# Altium schematic sheet Sheet2.SchDoc
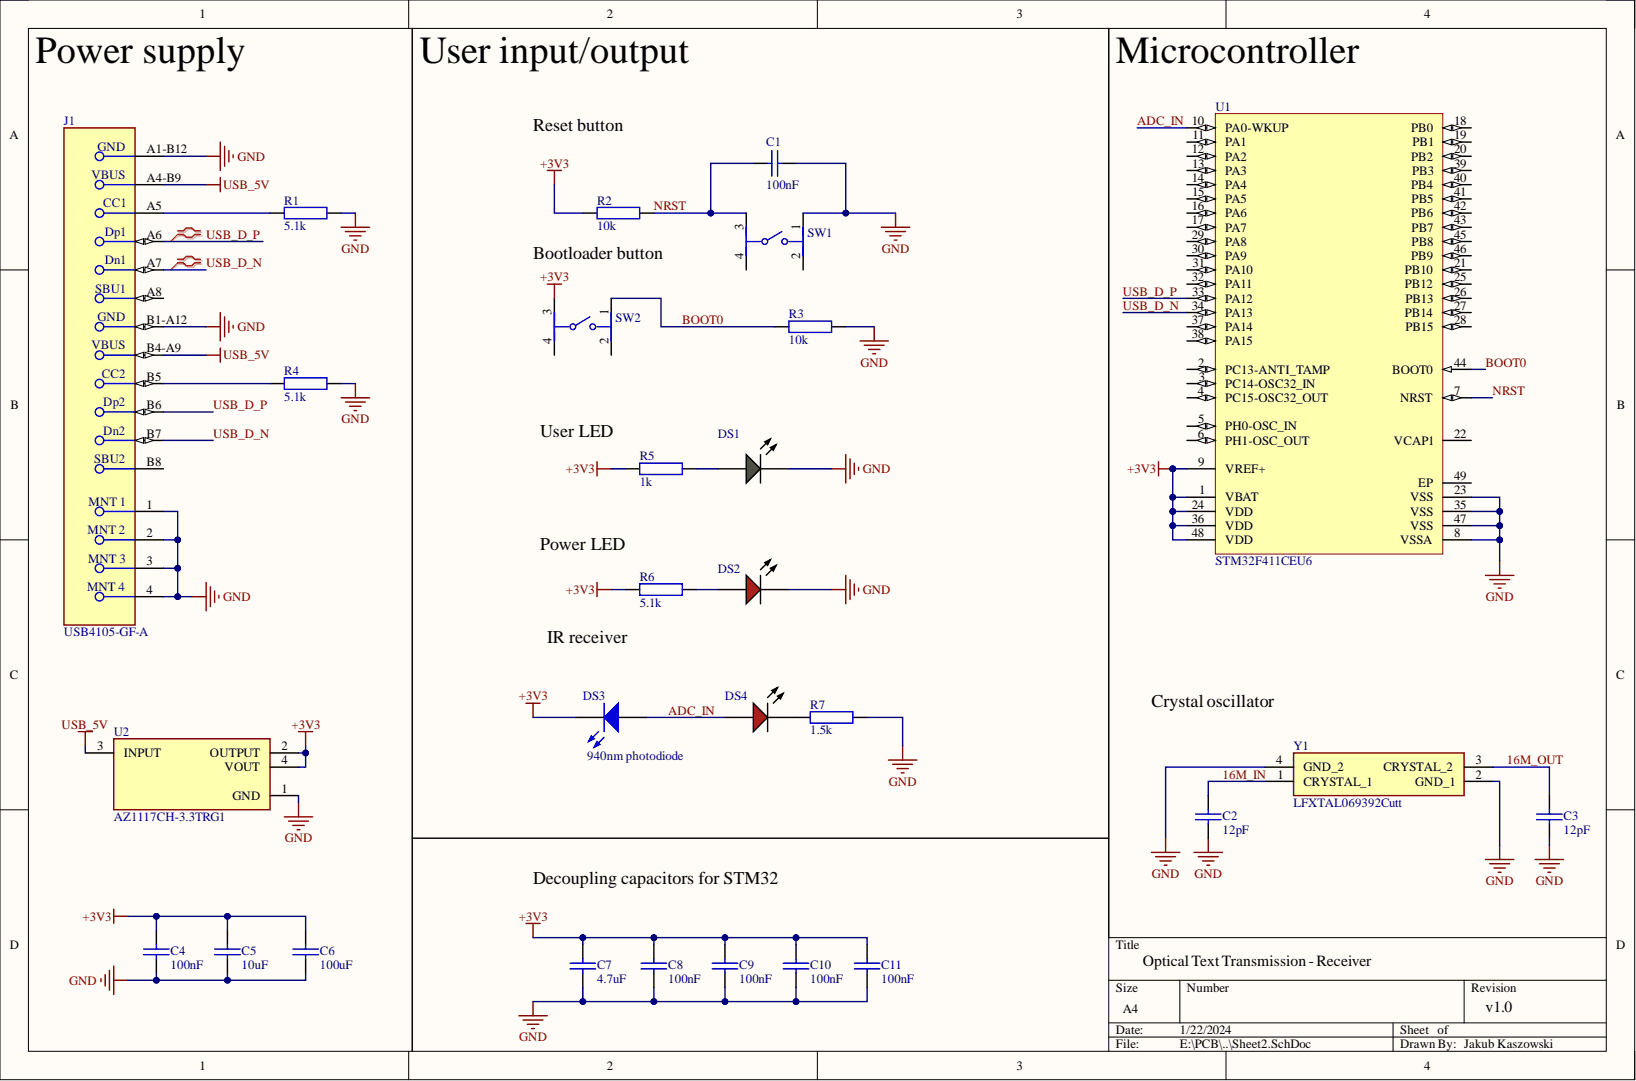
[diagram p0: Sheet2.SchDoc]

## Parts
C1  100nF  [C0603]
C2  12pF  [C0603]
C3  12pF  [C0603]
C4  100nF  [C0603]
C5  10uF  [C0603]
C6  100uF  [C1206]
C7  4.7uF  [C0603]
C8  100nF  [C0603]
C9  100nF  [C0603]
C10  100nF  [C0603]
C11  100nF  [C0603]
DS1  HSMG-C170  [AVAG-HSMX-C170_V]  (value not drawn)
DS2  TLMS1100-GS08  [VISH-TLMX1100_V]  (value not drawn)
DS3  940nm photodiode  [VISH-TSAL6200]
DS4  TLMS1100-GS08  [VISH-TLMX1100_V]  (value not drawn)
J1  USB4105-GF-A  [FP-USB4105-GF-A-MFG]
R1  5.1k  [R0603]
R2  10k  [R0603]
R3  10k  [R0603]
R4  5.1k  [R0603]
R5  1k  [R0603]
R6  5.1k  [R0603]
R7  1.5k  [R0603]
SW1  PTS810SJM250SMTRLFS  [FP-PTS810SJM250SMTRLFS-MFG]  (value not drawn)
SW2  PTS810SJM250SMTRLFS  [FP-PTS810SJM250SMTRLFS-MFG]  (value not drawn)
U1  STM32F411CEU6  [STM-UFQFPN48_L]
U2  AZ1117CH-3.3TRG1  [FP-SOT223-MFG]
Y1  LFXTAL069392Cutt  [LFXTAL069392Cutt]

## Nets
+3V3: C4.1 C5.1 C6.1 C7.1 C8.1 C9.1 C10.1 C11.1 DS3.2 R2.1 R5.1 R6.1 SW2.3 U1.1(VBAT) U1.9(VREF+) U1.24(VDD) U1.36(VDD) U1.48(VDD) U2.2(OUTPUT) U2.4(VOUT)
GND: C1.1 C2.2 C3.2 C4.2 C5.2 C6.2 C7.2 C8.2 C9.2 C10.2 C11.2 DS1.2 DS2.2 J1.1 J1.2 J1.3 J1.4 J1.A1-B12 J1.B1-A12 R1.2 R3.2 R4.2 R7.2 SW1.1 U1.8(VSSA) U1.23(VSS) U1.35(VSS) U1.47(VSS) U2.1(GND) Y1.2(GND_1) Y1.4(GND_2)
USB_5V: J1.A4-B9 J1.B4-A9 U2.3(INPUT)
16M_IN: C2.1 Y1.1(CRYSTAL_1)
16M_OUT: C3.1 Y1.3(CRYSTAL_2)
ADC_IN: DS3.1 DS4.1 U1.10(PA0-WKUP)
BOOT0: R3.1 SW2.1 U1.44(BOOT0)
NRST: C1.2 R2.2 SW1.3 U1.7(NRST)
USB_D_N: J1.A7 J1.B7 U1.34(PA13)
USB_D_P: J1.A6 J1.B6 U1.33(PA12)
NetDS1_1: DS1.1 R5.2
NetDS2_1: DS2.1 R6.2
NetDS4_2: DS4.2 R7.1
NetJ1_A5: J1.A5 R1.1
NetJ1_B5: J1.B5 R4.1

Not connected: J1 A8 B8; SW1 2 4; SW2 2 4; U1 2(PC13-ANTI_TAMP) 3(PC14-OSC32_IN) 4(PC15-OSC32_OUT) 5(PH0-OSC_IN) 6(PH1-OSC_OUT) 11(PA1) 12(PA2) 13(PA3) 14(PA4) 15(PA5) 16(PA6) 17(PA7) 18(PB0) 19(PB1) 20(PB2) 21(PB10) 22(VCAP1) 25(PB12) 26(PB13) 27(PB14) 28(PB15) 29(PA8) 30(PA9) 31(PA10) 32(PA11) 37(PA14) 38(PA15) 39(PB3) 40(PB4) 41(PB5) 42(PB6) 43(PB7) 45(PB8) 46(PB9) 49(EP)

## Library data of the parts
C1-C4 C8-C11 = 100nF 0603 5% X7R 50V: Ceramic capacitor SMD-0603 100nF /50V X7R# K +-5%, Samsung, RoHS; Manufacturer Part Number: CL10B104JB8NNNC; Dielectric: X7R; Tolerance: 5%; Voltage: 50V; Capacitance: 100nF; Case Code (Imperial): 0603; Case Code (Metric): 1608; Case/Package: 0603; Category: Ceramic Capacitors; Component Kind: Standard; ComponentLink1Description: https://datasheet.ciiva.com/25793/cl10b104jb8nnnc-25793394.pdf?src-supplier=Digi-Key; ComponentLink1URL: https://datasheet.ciiva.com/25793/cl10b104jb8nnnc-25793394.pdf?src-supplier=Digi-Key; ComponentLink2Description: https://datasheet.ciiva.com/26838/getdatasheetpartid-359321-26838402.pdf?src-supplier=Verical; ComponentLink2URL: https://datasheet.ciiva.com/26838/getdatasheetpartid-359321-26838402.pdf?src-supplier=Verical; ComponentLink3Description: https://datasheet.ciiva.com/16498/cl10b104jb8nnnc-16498485.pdf?src-supplier=Future+Electronics; ComponentLink3URL: https://datasheet.ciiva.com/16498/cl10b104jb8nnnc-16498485.pdf?src-supplier=Future+Electronics; ComponentLink4Description: https://datasheet.ciiva.com/29199/456613-da-01-en-vs-kondensator-0603-29199008.pdf?src-supplier=Conrad; ComponentLink4URL: https://datasheet.ciiva.com/29199/456613-da-01-en-vs-kondensator-0603-29199008.pdf?src-supplier=Conrad; Construction: Flat; Contact Plating: Tin; Depth: 0.8mm; Height: 0.8mm; Length: 1.6mm; Library Name: CAPACITORS.SchLib; Manufacturer: Samsung; Material: Ceramic; Max Operating Temperature: 125°C; Min Operating Temperature: -55°C; Mount: Surface Mount; Package Quantity: 1; Packaging: Tape and Reel; Pricing 1: 1=0.10000, 10=0.02700, 50=0.02700, 100=0.02200, 1000=0.01300, 10000=0.00800 (USD); Radiation Hardening: No; RoHS: Yes; RoHS Compliant: Yes; Series: CL; Stock 1: 410168; Supplier 1: Mouser; Supplier Part Number 1: 187-CL10B104JB8NNNC; Thickness: 0.035inch; Voltage Rating: 50V; Width: 0.031inch
C5 = 10uF 0603 10% X5R 10V: Cap Ceramic 10uF 10V X5R 10% SMD 0603 85°C Paper T/R; Manufacturer: Samsung; Manufacturer Part Number: CL10A106KP8NQSC; Category: Ceramic Capacitors; Component Kind: Standard; Library Name: CAPACITORS.SchLib; Pricing 1: 1=0.19000, 10=0.12800, 50=0.12800, 100=0.06500, 1000=0.04000, 10000=0.02700 (USD); RoHS: Yes; Stock 1: 69667; Supplier 1: Mouser; Supplier Part Number 1: 187-CL10A106KP8NQSC
C6 = 100uF 1206 20% X5R 6.3V: CL31 Series 1206 100 uF 6.3 V ±20% X5R Multilayer Ceramic Capacitor; Manufacturer: Samsung; Manufacturer Part Number: CL31A107MQHNNWE; Component Kind: Standard; Library Name: CAPACITORS.SchLib; Pricing 1: 1=0.49000, 10=0.34800, 50=0.34800, 100=0.20900, 1000=0.13500, 10000=0.10100 (USD); RoHS: Yes; RoHS Compliant: Yes; Stock 1: 73751; Supplier 1: Mouser; Supplier Part Number 1: 187-CL31A107MQHNNWE
C7 = 4.7uF 0603 10% X5R 10V: Cap Ceramic 4.7uF 10V X5R 10% Pad SMD 0603 85C T/R; Manufacturer: Samsung; Manufacturer Part Number: CL10A475KP8NNNC; Capacitance: 4.7uF; Case Code (Imperial): 0603; Case Code (Metric): 1608; Case/Package: 0603; Category: Ceramic Capacitors; Component Kind: Standard; ComponentLink1Description: https://datasheet.ciiva.com/25797/cl10a475kp8nnnc-25797520.pdf?src-supplier=Digi-Key; ComponentLink1URL: https://datasheet.ciiva.com/25797/cl10a475kp8nnnc-25797520.pdf?src-supplier=Digi-Key; ComponentLink2Description: https://datasheet.ciiva.com/26838/getdatasheetpartid-358676-26838384.pdf?src-supplier=Verical; ComponentLink2URL: https://datasheet.ciiva.com/26838/getdatasheetpartid-358676-26838384.pdf?src-supplier=Verical; ComponentLink3Description: https://datasheet.ciiva.com/16318/cl05b104kq5nnnc-16318245.pdf?src-supplier=Future+Electronics; ComponentLink3URL: https://datasheet.ciiva.com/16318/cl05b104kq5nnnc-16318245.pdf?src-supplier=Future+Electronics; Construction: Flat; Contact Plating: Tin; Depth: 0.8mm; Dielectric: X5R; Height: 0.8mm; Length: 1.6mm; Library Name: CAPACITORS.SchLib; Max Operating Temperature: 85°C; Min Operating Temperature: -55°C; Mount: Surface Mount; Package Quantity: 1; Packaging: Tape and Reel; Pricing 1: 1=0.10000, 10=0.02600, 50=0.02600, 100=0.02100, 1000=0.01200, 10000=0.00800 (USD); Radiation Hardening: No; RoHS: Yes; RoHS Compliant: Yes; Series: CL; Stock 1: 24900; Supplier 1: Mouser; Supplier Part Number 1: 187-CL10A475KP8NNNC; Thickness: 0.035inch; Tolerance: 10%; Voltage Rating: 10V; Width: 0.031inch
DS1 = CMP-2000-05062-1: Chip LED, Green, 2.2 V, -40 to 85 degC, 2-Pin SMD, Pb-Free, Tape and Reel; Manufacturer: Avago; Bulb Size: 1.25mmx1.4mm; Dominant Wavelength: 572nm; External Depth: 0.8mm; External Length / Height: 2mm; External Width: 1.25mm; Forward Current If: 20mA; Forward Voltage: 2.2V; Forward Voltage VF Max: 2.6V; LED Type: Standard; Lens Shape: Rectangular; Light Area Height: 1mm; Luminous Intensity: 15mcd; Luminous Intensity Min: 4mcd; No. of Pins: 2; Opto Mounting Orientation: Top; Peak Wavelength: 570nm; PIV Max: 5V; SVHC: NoSVHC(16-Jun-2014); Viewing Angle: 170deg; Component Kind: Standard; Datasheet URL: https://datasheet.ciiva.com/29280/180495-da-01-en-chip-led-hsmg-c170-gelb-gruen-29280971.pdf; Datasheet Version: Oct-08; Dominant Wavelength Typ: 572nm; Forward Current If Max: 20mA; LED Colour: Green; LED Mounting: SMD; Library Name: Altium Content Vault; Luminous Intensity Typ: 15mcd; Manufacturer URL: http://www.avagotech.com/; Mounting Type: SMD; MSL: MSL2A-4weeks; Operating Temperature Max: 85degC; Operating Temperature Min: -30degC; Operating Temperature Range: -30degCto+85degC; Opto Case Style: SMD; Package Description: 2-Pin Surface Mount Device, Body 2 x 1.25 mm, Height 0.8 mm; Package Reference: HSMX-C170; Packaging: CutTape; Peak Forward Current: 20mA; Storage Temperature Max: 85degC; Storage Temperature Min: -40degC; Voltage Vf Typ: 2.2V; Wavelength Typ: 570nm
DS2 DS4 = CMP-1666-00027-1: LED, RED, 2.1 V, -40 to 100 degC, 2-Pin SMD (0603), RoHS, Tape and Reel; Capacitance: 12 pF; Resistance: 100000000000 Ohm; Tolerance: 5%; Type (Capacitors): C0G; Voltage Rating: 50 V; Case Code (Imperial): 0603; Case Code (Metric): 1608; Case/Package: 0603; Component Kind: Standard; ComponentLink1Description: Manufacturer URL; ComponentLink1URL: http://www.vishay.com/; ComponentLink2Description: Datasheet; ComponentLink2URL: http://www.vishay.com/docs/83173/tlmb1100.pdf; DatasheetVersion: Rev. 2.7, 03/2016; Height: 0.8 mm; Length: 1.6 mm; Library Name: Altium Content Vault; Max Operating Temperature: 125degC; Min Operating Temperature: -55degC; Mount: Surface Mount; Package Quantity: 4000; PackageDescription: 2-Pin Surface Mount Device, Body 1.6 x 0.8 mm; PackageReference: TLMX1100; Packaging: Tape and Reel; Pins: 2; Radiation Hardening: No; REACH SVHC: No SVHC; RoHSCompliant: True; Voltage Rating (DC): 50 V; Width: 0.8 mm
DS3 = CMP-2000-07327-1: High Power Infrared Emitting Diode, GaAlAs, MQW, 1.35 V, 100 mA, -40 to 85 degC, 2-Pin THD, RoHS, Bulk; Manufacturer: Vishay; DELETED: Compliant; Forward Current: 100mA; Forward Voltage: 3V; High Brightness: Yes; Lens Type: Colored; Lum Intensity: 500mW/srmcd; Luminous Intensity: 500mW/sr; Main Category: IrLED; Number of  Elements: 1; Peak Wavelength: 950nm; Power Dissipation: 160W; Product Depth (mm): 5.8mm; Product Height (mm): 8.7mm; Rad Hardened: No; Reverse Voltage: 5V; Shape Type: Circular; Viewing Angle: 34deg; Chip Material: GaAlAs; Component Kind: Standard; Datasheet URL: http://www.vishay.com/docs/81010/tsal6200.pdf; Datasheet Version: Rev. 2.4, 03/2014; Lens Dimensions: 5x5x7.7mm; Library Name: Altium Content Vault; Manufacturer URL: http://www.vishay.com/; Mounting: ThroughHole; Mounting Technology: ThroughHole; Operating Temp Range: -40Cto85C; Operating Temperature Classification: Industrial; Package Description: 2-Pin Through Hole Device, Dia 5.8 mm, Pitch 2.54 mm; Package Reference: TSAL6200; Package Type: T-13/4; Packaging: Bulk; Pin Count: 2; Product Length (mm): 5.8mm; Test Current (It): 100mA; Type: IrLED
J1 = CMP-245163-000001-1: CONN RCPT USB2.0 TYPEC 5A; Manufacturer: GCT; Conn Orientation: Right Angle; Current Rating: 5/6.25/1.25/0.25A; Is Female: yes; Number Of Contacts: 16; Voltage Rating: 20V; Automotive: No; Category: Conn; Component Kind: Standard; ComponentLink2Description: Datasheet; ComponentLink2URL: https://gct.co/files/drawings/usb4105.pdf; Contact Material: Gold,Nickel; Device Class L1: Connectors; Device Class L2: USB Connectors; Device Class L3: unset; Digikey Description: CONN RCPT USB2.0 TYPEC 5A; Height: 3.46mm; Lead Free: Yes; Library Name: Altium Content Vault; Manufacturer Part Number: USB4105-GF-A; Octopart: https://octopart.com/usb4105-gf-a-gct-106627878; Package: 0; RoHS: TRUE; Temperature: -40°C to +85°C; Temperature Range High: +85°C; Temperature Range Low: -40°C; Usb Standard: USB 2.0
R1 R4 R6 = 5.1k 0603 1% 1/10W: Res Thick Film 0603 5.1K Ohm 1% 1/10W ±100ppm/C Molded SMD SMD Paper Tape on Plastic Reel; Manufacturer: Bourns; Manufacturer Part Number: CR0603-FX-5101ELF; Max Power Dissipation: 100mW; Resistance: 5.1kR; Temperature Coefficient: 100ppm/°C; Voltage Rating: 50V; Case Code (Imperial): 0603; Case Code (Metric): 1608; Case/Package: 0603; Category: Chip SMD Resistors; Component Kind: Standard; ComponentLink1Description: https://datasheet.ciiva.com/16939/chpreztr-16939916.pdf?src-supplier=Avnet+Europe; ComponentLink1URL: https://datasheet.ciiva.com/16939/chpreztr-16939916.pdf?src-supplier=Avnet+Europe; Construction: Rectangular; Contact Plating: Tin; Depth: 0.8mm; Features: Moisture Resistant; Height: 0.45mm; Length: 1.6mm; Library Name: RESISTORS.SchLib; Max Operating Temperature: 155°C; Military Standard: Not; Min Operating Temperature: -55°C; Mount: Surface Mount; Number of Terminations: 2; Package Quantity: 5000; Packaging: Tape and Reel; Power Rating: 100mW; Pricing 1: 1=0.10000, 10=0.01400, 50=0.01400, 100=0.00600, 1000=0.00200, 10000=0.00200 (USD); Radiation Hardening: No; REACH SVHC: No SVHC; Resistance Tolerance: 1%; Resistor Type: Thick Film; RoHS: Yes; RoHS Compliant: Yes; Series: CR0603; Stock 1: 107525; Supplier 1: Mouser; Supplier Part Number 1: 652-CR0603FX-5101ELF; Termination: SMD/SMT; Thickness: 457um; Tolerance: 1%; Voltage Rating (DC): 50V; Width: 0.8mm
R2 R3 = 10k 0603 1% 1/10W: CRGCQ 0603 10K 1%; Manufacturer: TE Connectivity; Manufacturer Part Number: CRGCQ0603F10K; Category: Chip SMD Resistors; Component Kind: Standard; ComponentLink1Description: https://4donline.ihs.com/images/VipMasterIC/IC/TYEL/TYEL-S-A0004929575/TYEL-S-A0004929575-1.pdf?hkey=52A5661711E402568146F3353EA87419&src-supplier=Farnell; ComponentLink1URL: https://4donline.ihs.com/images/VipMasterIC/IC/TYEL/TYEL-S-A0004929575/TYEL-S-A0004929575-1.pdf?hkey=52A5661711E402568146F3353EA87419&src-supplier=Farnell; ComponentLink2Description: https://4donline.ihs.com/images/VipMasterIC/IC/TYEL/TYEL-S-A0004929575/TYEL-S-A0004929575-1.pdf?hkey=52A5661711E402568146F3353EA87419&src-supplier=Newark; ComponentLink2URL: https://4donline.ihs.com/images/VipMasterIC/IC/TYEL/TYEL-S-A0004929575/TYEL-S-A0004929575-1.pdf?hkey=52A5661711E402568146F3353EA87419&src-supplier=Newark; ComponentLink3Description: https://www.te.com/commerce/DocumentDelivery/DDEController?Action=srchrtrv&DocNm=1773204-3&DocType=DS&DocLang=English&src-supplier=Digi-Key; ComponentLink3URL: https://www.te.com/commerce/DocumentDelivery/DDEController?Action=srchrtrv&DocNm=1773204-3&DocType=DS&DocLang=English&src-supplier=Digi-Key; Library Name: RESISTORS.SchLib; Pricing 1: 1=0.10000, 10=0.03000, 50=0.03000, 100=0.01200, 1000=0.00400, 10000=0.00300 (USD); RoHS: Yes; RoHS Compliant: Yes; Stock 1: 24230; Supplier 1: Mouser; Supplier Part Number 1: 279-CRGCQ0603F10K
R5 = 1k 0603 1% 1/10W: BOURNS - CR0603-FX-1001ELF - SMD Chip Resistor, 0603 [1608 Metric], 1 kohm, CR Series, 50 V, Thick Film, 100 mW; Manufacturer Series: CR0603; Max Power Dissipation: 100mW; Resistance: 1kR; Resistance Tolerance: 1%; Temperature Coefficient: 100ppm/°C; Voltage Rating: 50V; Case Code (Imperial): 0603; Case Code (Metric): 1608; Case/Package: 0603; Category: Chip SMD Resistors; Component Kind: Standard; ComponentLink1Description: https://datasheet.ciiva.com/11808/0900766b80fcdb72-11808035.pdf?src-supplier=RSComponents; ComponentLink1URL: https://datasheet.ciiva.com/11808/0900766b80fcdb72-11808035.pdf?src-supplier=RSComponents; ComponentLink2Description: https://datasheet.ciiva.com/19466/774882-19466523.pdf?src-supplier=Jameco; ComponentLink2URL: https://datasheet.ciiva.com/19466/774882-19466523.pdf?src-supplier=Jameco; ComponentLink3Description: https://datasheet.ciiva.com/6547/chpreztr-6547349.pdf?src-supplier=Digi-Key; ComponentLink3URL: https://datasheet.ciiva.com/6547/chpreztr-6547349.pdf?src-supplier=Digi-Key; ComponentLink4Description: https://datasheet.ciiva.com/16941/chpreztr-16941637.pdf?src-supplier=Avnet+Europe; ComponentLink4URL: https://datasheet.ciiva.com/16941/chpreztr-16941637.pdf?src-supplier=Avnet+Europe; ComponentLink5Description: https://datasheet.ciiva.com/22693/1902866-22693205.pdf?src-supplier=Element14; ComponentLink5URL: https://datasheet.ciiva.com/22693/1902866-22693205.pdf?src-supplier=Element14; ComponentLink6Description: https://datasheet.ciiva.com/6547/chpreztr-6547350.pdf?src-supplier=Digi-Key; ComponentLink6URL: https://datasheet.ciiva.com/6547/chpreztr-6547350.pdf?src-supplier=Digi-Key; ComponentLink7Description: https://datasheet.ciiva.com/7001/1902866-7001622.pdf?src-supplier=Newark; ComponentLink7URL: https://datasheet.ciiva.com/7001/1902866-7001622.pdf?src-supplier=Newark; ComponentLink8Description: https://datasheet.ciiva.com/30115/159chpreztr-30115222.pdf?src-supplier=Onlinecomponents.com; ComponentLink8URL: https://datasheet.ciiva.com/30115/159chpreztr-30115222.pdf?src-supplier=Onlinecomponents.com; ComponentLink9Description: https://datasheet.ciiva.com/6547/chpreztr-6547351.pdf?src-supplier=Digi-Key; ComponentLink9URL: https://datasheet.ciiva.com/6547/chpreztr-6547351.pdf?src-supplier=Digi-Key; ComponentLink10Description: https://datasheet.ciiva.com/16757/70154685-16757482.pdf?src-supplier=Allied+Electronics; ComponentLink10URL: https://datasheet.ciiva.com/16757/70154685-16757482.pdf?src-supplier=Allied+Electronics; ComponentLink11Description: https://datasheet.ciiva.com/5546/chpreztr-5546283.pdf?src-supplier=Mouser; ComponentLink11URL: https://datasheet.ciiva.com/5546/chpreztr-5546283.pdf?src-supplier=Mouser; ComponentLink12Description: https://datasheet.ciiva.com/7986/691949-7986156.pdf?src-supplier=Element14; ComponentLink12URL: https://datasheet.ciiva.com/7986/691949-7986156.pdf?src-supplier=Element14; ComponentLink13Description: https://datasheet.ciiva.com/3255/chpreztr-3255917.pdf?src-supplier=Avnet; ComponentLink13URL: https://datasheet.ciiva.com/3255/chpreztr-3255917.pdf?src-supplier=Avnet; Construction: Rectangular; Contact Plating: Tin; Depth: 0.8mm; Features: Moisture Resistant; Height: 0.45mm; Length: 1.6mm; Library Name: RESISTORS.SchLib; Manufacturer: Bourns; Manufacturer Part Number: CR0603-FX-1001ELF; Max Operating Temperature: 155°C; Military Standard: Not; Min Operating Temperature: -55°C; Mount: Surface Mount; Number of Pins: 2; Number of Terminations: 2; Package Quantity: 1; Package Shape: Rectangular; Packaging: Tape and Reel; Power Rating: 100mW; Radiation Hardening: No; REACH SVHC: No SVHC; Resistor Type: Thick Film; RoHS: Yes; RoHS Compliant: Yes; Series: CR0603; Size Code: 0603; Stock 1: 178368; Supplier 1: Mouser; Supplier Part Number 1: 652-CR0603FX-1001ELF; Technology: THICK FILM; Terminal Finish: Tin; Termination: SMD/SMT; Thickness: 457um; Tolerance: 1%; Voltage Rating (DC): 50V; Width: 0.8mm; Working Voltage: 50V
R7 = 1.5k 0603 0.1% 1/5W: Res Thick Film 0603 1.5K Ohm 0.1% 0.2W(1/5W) ±50ppm/C Pad SMD Automotive T/R; Manufacturer: Panasonic; Manufacturer Part Number: ERJ-PB3B1501V; Max Power Dissipation: 200mW; Resistance: 1.5kR; Temperature Coefficient: 50ppm/°C; Case Code (Imperial): 0603; Case Code (Metric): 1608; Case/Package: 0603; Category: Chip SMD Resistors; Component Kind: Standard; ComponentLink1Description: https://industrial.panasonic.com/sa/system/files/data/download/catalog/id_fixed-resistors_e_2.pdf?src-supplier=Digi-Key; ComponentLink1URL: https://industrial.panasonic.com/sa/system/files/data/download/catalog/id_fixed-resistors_e_2.pdf?src-supplier=Digi-Key; ComponentLink2Description: https://industrial.panasonic.com/cdbs/www-data/pdf/RDM0000/DMM0000COL5.pdf?src-supplier=Digi-Key; ComponentLink2URL: https://industrial.panasonic.com/cdbs/www-data/pdf/RDM0000/DMM0000COL5.pdf?src-supplier=Digi-Key; Features: Automotive AEC-Q200; Height - Seated (Max): 0.022inch; Length: 0.063inch; Library Name: RESISTORS.SchLib; Max Operating Temperature: 155°C; Min Operating Temperature: -55°C; Number of Terminations: 2; Packaging: Tape and Reel; Pricing 1: 1=0.25000, 10=0.15300, 50=0.11100, 100=0.06300, 1000=0.03400, 10000=0.02400 (USD); Resistor Type: Thick Film; RoHS: Yes; Stock 1: 11796; Supplier 1: Mouser; Supplier Part Number 1: 667-ERJ-PB3B1501V; Tolerance: 0.1%; Width: 0.032inch
SW1 SW2 = CMP-10040-000001-2: SWITCH TACTILE SPST-NO 0.05A 16V; Actuator Type: Standard; Current Rating: 50mA; Illumination Type: Non-Illuminated; Mechanical Life: 150000Cycles; Operating Force: 160gf; Switch Function: Off-Mom; Switch Travel: 0.15mm; Throw Configuration: SPST; Case/Package: SMD/SMT; Color: Black; Component Kind: Standard; Contact Plating: Silver; Depth: 4.6mm; Lead Free: Lead Free; Length: 3.2mm; Library Name: Altium Content Vault; Max Current Rating: 50mA; Max Operating Temperature: 85°C; Min Operating Temperature: -40°C; Mount: Surface Mount; Orientation: Straight; Package Quantity: 2900; Packaging: Tape and Reel; RoHS Compliant: Yes; Termination: J-Bend; Voltage Rating (DC): 16V
U1 = CMP-0243-00165-1: ARM Cortex-M4 32-bit MCU+FPU, 512 KB Flash, 128 KB RAM, 36 I/Os, 48-Pin UFQFPN, -40 to 85 degC, Tray; FLASH Size (Prog) (kB): 512; I/Os (High Current): 36; Internal RAM Size (kB): 128; Operating Frequency(F) (Processor speed) (MHz): 100; Supply Voltage(Vcc) min (V): 1.7; Component Kind: Standard; ComponentLink1Description: Manufacturer URL; ComponentLink1URL: http://www.st.com/; ComponentLink2Description: Datasheet; ComponentLink2URL: http://www.st.com/st-web-ui/static/active/en/resource/technical/document/datasheet/DM00115249.pdf; Core: ARM Cortex-M4; DatasheetVersion: Rev. 6; Library Name: Altium Content Vault; Mounting Technology: Surface Mount; Package Description: UFQFPN48; Package Reference: 48-Pin Ultra Thin Fine Pitch Quad Flat No-Lead, 7 x 7 x 0.6 mm Body, 0.5 mm Pitch, Exposed Pad; Packing: Tray
U2 = CMP-12756-000028-1: IC REG LINEAR 3.3V 800MA SOT223; Accuracy: 1%; Dropout Voltage: 1.2V; Max Input Voltage: 15V; Max Output Current: 1.35A; Min Current Limit: 1A; Number of Outputs: 1; Output Type: Fixed; Polarity: Positive; Power Supply Rejection Ratio (PSRR): 70dB; Quiescent Current: 6mA; Reference Voltage: 1.262V; Case/Package: SOT-223; Component Kind: Standard; Height: 1.7mm; Lead Free: Lead Free; Length: 6.7mm; Library Name: Altium Content Vault; Max Operating Temperature: 125°C; Min Operating Temperature: -20°C; Mount: Surface Mount; Number of Pins: 4; Number of Regulators: 1; Output Current: 800mA; Output Voltage: 3.3V; Package Quantity: 4000; Packaging: Tape and Reel; Width: 3.7mm
Y1 = LFXTAL069392Cutt: Crystal or Oscillator; Manufacturer_Name: IQD; Arrow Part Number: LFXTAL069392CUTT; Arrow Price/Stock: https://www.arrow.com/en/products/lfxtal069392cutt/iqd-frequency-products; Component Kind: Standard; Datasheet Link: https://componentsearchengine.com/Datasheets/1/LFXTAL069392Cutt.pdf; Height: 0.6mm; Manufacturer_Part_Number: LFXTAL069392Cutt; Mouser Part Number: 449-LFXTAL069392CUTT; Mouser Price/Stock: https://www.mouser.co.uk/ProductDetail/IQD/LFXTAL069392Cutt?qs=nFovR%252B4R4UNxw2KKrfwNAQ%3D%3D
